# Altium schematic sheet 9-Other_Inputs.SchDoc(9-Other_Inputs)
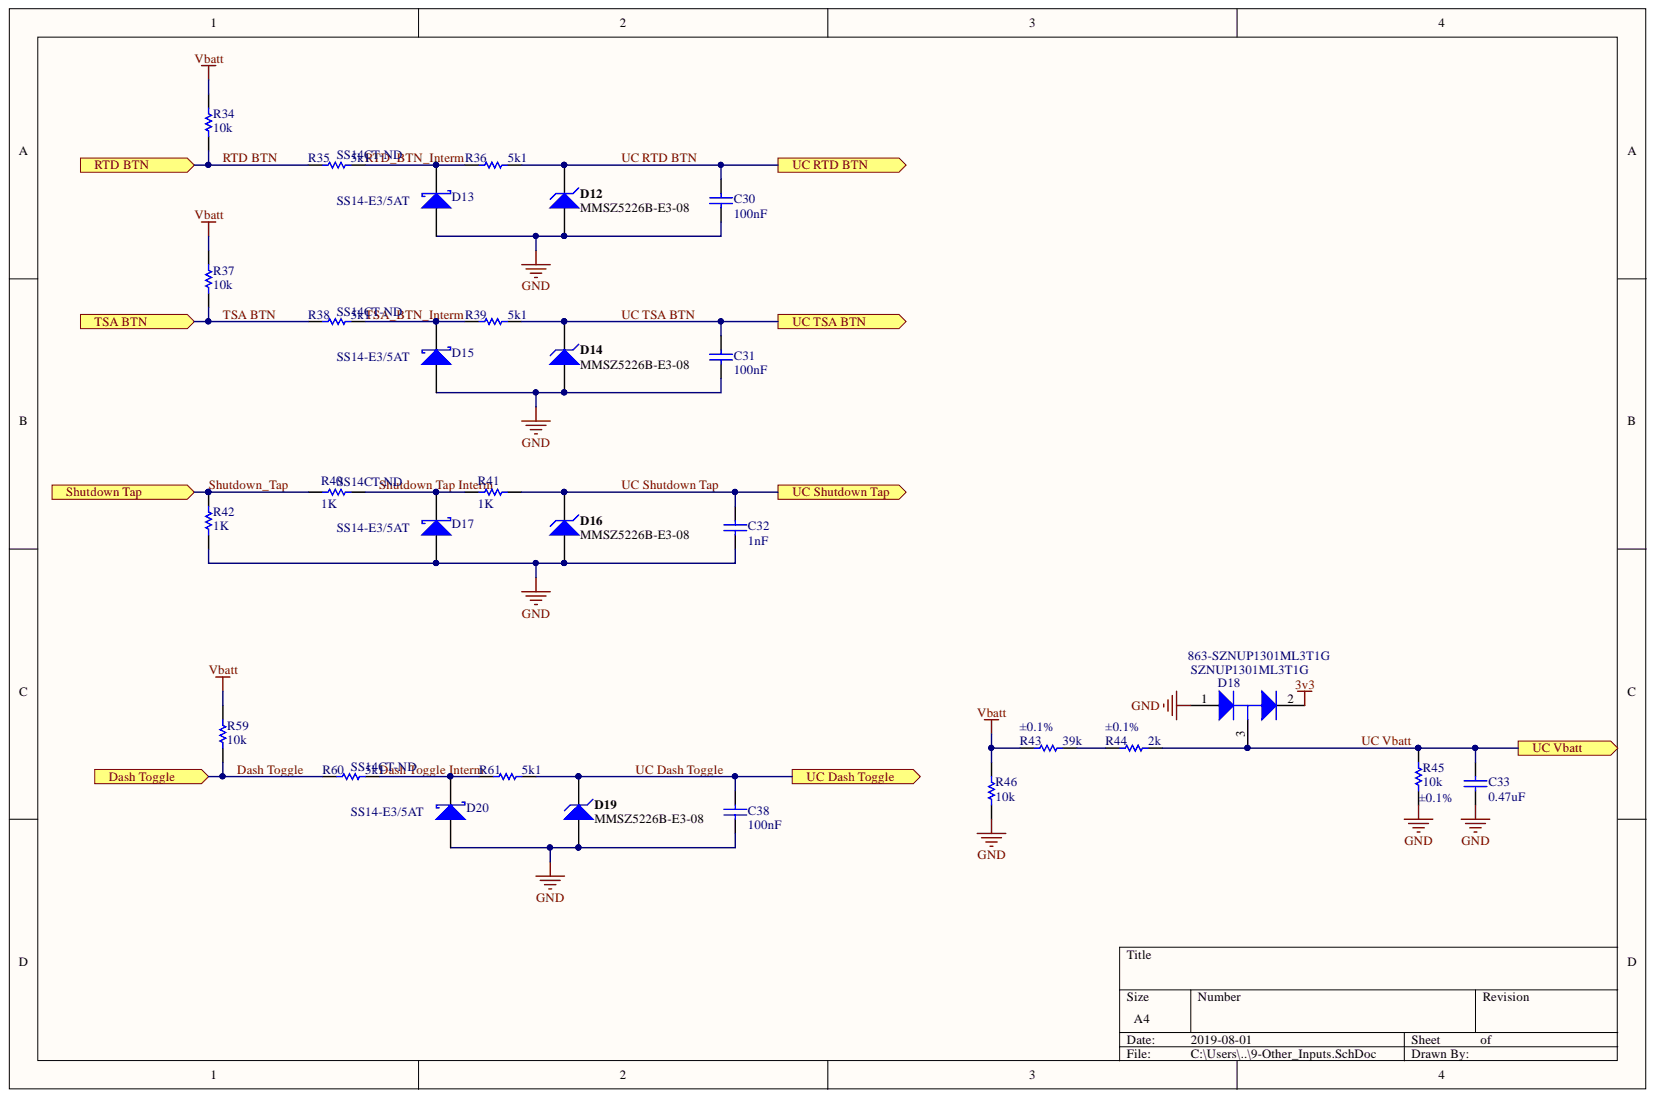
[diagram p0: 9-Other_Inputs.SchDoc]

## Parts
C30  value 100nF  [1608[0603]]  (hidden comment: Cap)
C31  value 100nF  [1608[0603]]  (hidden comment: Cap)
C32  value 1nF  [1608[0603]]  (hidden comment: Cap)
C33  value 0.47uF  [1608[0603]]  (hidden comment: Cap)
C38  value 100nF  [1608[0603]]  (hidden comment: Cap)
D12  MMSZ5226B-E3-08  [VISH-SOD-123-2_V]
D13  SS14-E3/5AT  [VISH-DO-214AC-2_V]
D14  MMSZ5226B-E3-08  [VISH-SOD-123-2_V]
D15  SS14-E3/5AT  [VISH-DO-214AC-2_V]
D16  MMSZ5226B-E3-08  [VISH-SOD-123-2_V]
D17  SS14-E3/5AT  [VISH-DO-214AC-2_V]
D18  SZNUP1301ML3T1G  [ONSC-SOT-23-3-318-08_V]
D19  MMSZ5226B-E3-08  [VISH-SOD-123-2_V]
D20  SS14-E3/5AT  [VISH-DO-214AC-2_V]
R34  value 10k  [1608[0603]]  (hidden comment: Res1)
R35  value 5k1  [1608[0603]]  (hidden comment: Res1)
R36  value 5k1  [1608[0603]]  (hidden comment: Res1)
R37  value 10k  [1608[0603]]  (hidden comment: Res1)
R38  value 5k1  [1608[0603]]  (hidden comment: Res1)
R39  value 5k1  [1608[0603]]  (hidden comment: Res1)
R40  value 1K  [1608[0603]]  (hidden comment: Res1)
R41  value 1K  [1608[0603]]  (hidden comment: Res1)
R42  value 1K  [1608[0603]]  (hidden comment: Res1)
R43  value 39k  Tolerance: ±0.1%  [1608[0603]]  (hidden comment: Res1)
R44  value 2k  Tolerance: ±0.1%  [1608[0603]]  (hidden comment: Res1)
R45  value 10k  Tolerance: ±0.1%  [1608[0603]]  (hidden comment: Res1)
R46  value 10k  [1608[0603]]  (hidden comment: Res1)
R59  value 10k  [1608[0603]]  (hidden comment: Res1)
R60  value 5k1  [1608[0603]]  (hidden comment: Res1)
R61  value 5k1  [1608[0603]]  (hidden comment: Res1)

## Ports
Dash Toggle, RTD BTN, Shutdown Tap, TSA BTN, UC Dash Toggle, UC RTD BTN, UC Shutdown Tap, UC TSA BTN, UC Vbatt

## Nets
3v3: D18.2
GND: C30.1 C31.1 C32.1 C33.1 C38.1 D12.1 D13.1 D14.1 D15.1 D16.1 D17.1 D18.1 D19.1 D20.1 R42.1 R45.1 R46.1
Vbatt: R34.2 R37.2 R43.1 R46.2 R59.2
Dash Toggle: R59.1 R60.1 ; port Dash Toggle
Dash Toggle Interm: D20.2 R60.2 R61.1
RTD BTN: R34.1 R35.1 ; port RTD BTN
RTD_BTN_Interm: D13.2 R35.2 R36.1
Shutdown Tap Interm: D17.2 R40.1 R41.2
Shutdown_Tap: R40.2 R42.2 ; port Shutdown Tap
TSA BTN: R37.1 R38.1 ; port TSA BTN
TSA_BTN_Interm: D15.2 R38.2 R39.1
UC Dash Toggle: C38.2 D19.2 R61.2 ; port UC Dash Toggle
UC RTD BTN: C30.2 D12.2 R36.2 ; port UC RTD BTN
UC Shutdown Tap: C32.2 D16.2 R41.1 ; port UC Shutdown Tap
UC TSA BTN: C31.2 D14.2 R39.2 ; port UC TSA BTN
UC Vbatt: C33.2 D18.3 R44.2 R45.2 ; port UC Vbatt
NetR43_2: R43.2 R44.1

## Library data of the parts
C30 C31 C38 = Cap: Capacitor; Manufacturer Part Number: CC0603KRX7R9BB104; Manufacturer: Yageo; Voltage - Rated: 50V; Tolerance: ±10%; Temperature Coefficient: X7R; Capacitance: 0.10µF; Thickness (Max): 0.035" (0.90mm); Supplier Part Number 1: 311-1344-1-ND; Supplier 1: Digi-Key; Stock 1: 4016262; Size / Dimension: 0.063" L x 0.031" W (1.60mm x 0.80mm); Simulation: CAP; Signal Integrity: Cap; RoHS: RoHS Compliant; Ratings: -; Pricing 1: 1=0.15, 10=0.043, 25=0.0312, 50=0.0232, 100=0.0189, 250=0.0158, 500=0.01346, 1000=0.01059 (CAD); Part Status: Active; Packaging: Cut Tape (CT); Package / Case: 0603 (1608 Metric); Operating Temperature: -55°C ~ 125°C; Mounting Type: Surface Mount, MLCC; Library Name: Miscellaneous Devices.IntLib; Lead Style: -; Lead Spacing: -; Height - Seated (Max): -; Features: -; Failure Rate: -; ComponentLink1URL: http://www.yageo.com/documents/recent/UPY-GPHC_X7R_6.3V-to-50V_16.pdf; ComponentLink1Description: http://www.yageo.com/documents/recent/UPY-GPHC_X7R_6.3V-to-50V_16.pdf; Component Kind: Standard; Category: Capacitors; Applications: General Purpose
C32 = Cap: Capacitor; Manufacturer Part Number: CC0603KRX7R9BB102; Manufacturer: Yageo; Voltage - Rated: 50V; Tolerance: ±10%; Temperature Coefficient: X7R; Capacitance: 1000pF; Thickness (Max): 0.035" (0.90mm); Supplier Part Number 1: 311-1080-1-ND; Supplier 1: Digi-Key; Stock 1: 783486; Size / Dimension: 0.063" L x 0.031" W (1.60mm x 0.80mm); Simulation: CAP; Signal Integrity: Cap; RoHS: RoHS Compliant; Ratings: -; Pricing 1: 1=0.15, 10=0.029, 25=0.0212, 50=0.0158, 100=0.0129, 250=0.01084, 500=0.00928, 1000=0.00729 (CAD); Part Status: Active; Packaging: Cut Tape (CT); Package / Case: 0603 (1608 Metric); Operating Temperature: -55°C ~ 125°C; Mounting Type: Surface Mount, MLCC; Library Name: Miscellaneous Devices.IntLib; Lead Style: -; Lead Spacing: -; Height - Seated (Max): -; Features: -; Failure Rate: -; ComponentLink1URL: http://www.yageo.com/documents/recent/UPY-GPHC_X7R_6.3V-to-50V_16.pdf; ComponentLink1Description: http://www.yageo.com/documents/recent/UPY-GPHC_X7R_6.3V-to-50V_16.pdf; Component Kind: Standard; Category: Capacitors; Applications: General Purpose
C33 = Cap: Capacitor; Manufacturer Part Number: CC0603KRX7R8BB474; Manufacturer: Yageo; Voltage - Rated: 25V; Tolerance: ±10%; Temperature Coefficient: X7R; Capacitance: 0.47µF; Thickness (Max): 0.035" (0.90mm); Supplier Part Number 1: 311-1805-1-ND; Supplier 1: Digi-Key; Stock 1: 11076; Size / Dimension: 0.063" L x 0.031" W (1.60mm x 0.80mm); Simulation: CAP; Signal Integrity: Cap; RoHS: RoHS Compliant; Ratings: -; Pricing 1: 1=0.22, 10=0.158, 25=0.1156, 50=0.0898, 100=0.0745, 250=0.06168, 500=0.05226, 1000=0.04324 (CAD); Part Status: Active; Packaging: Cut Tape (CT); Package / Case: 0603 (1608 Metric); Operating Temperature: -55°C ~ 125°C; Mounting Type: Surface Mount, MLCC; Library Name: Miscellaneous Devices.IntLib; Lead Style: -; Lead Spacing: -; Height - Seated (Max): -; Features: -; Failure Rate: -; ComponentLink1URL: http://www.yageo.com/documents/recent/UPY-GPHC_X7R_6.3V-to-50V_16.pdf; ComponentLink1Description: http://www.yageo.com/documents/recent/UPY-GPHC_X7R_6.3V-to-50V_16.pdf; Component Kind: Standard; Category: Capacitors; Applications: General Purpose
D12 D14 D16 D19 = CMP-0931-00026-1: Small Signal Zener Diode, 3.3 V +/- 5%, -55 to 155 degC, 2-Pin SOD-123, RoHS, Tape and Reel; Manufacturer Part Number: MMSZ5226B-7-F; Manufacturer: Vishay Semiconductor; Zener Voltage (NOM.) (V): 3.3; Zener Impedance (IZT1) (Max.) (Ohm): 28; Voltage - Zener (Nom) (Vz): 3.3V; Voltage - Forward (Vf) (Max) @ If: 900mV @ 10mA; Tolerance: +/- 5%; Test Current (IZT1) (mA): 20; Power Dissipation (mW): 500; Power - Max: 500mW; Int. Construction: Single; Impedance (Max) (Zzt): 28 Ohm; Current - Reverse Leakage @ Vr: 25µA @ 1V; Supplier Part Number 1: MMSZ5226B-FDICT-ND; Supplier Device Package: SOD-123; Supplier 1: Digi-Key; Stock 1: 45935; RoHS: TRUE; Pricing 1: 1=0.29, 10=0.263, 100=0.1426, 500=0.08778, 1000=0.05985 (CAD); Part Status: Active; PartNumber: MMSZ5226B-E3-08; Packing: Tape and Reel; Packaging: Cut Tape (CT); PackageVersion: Rev. 1.9; PackageReference: SOD-123-2; PackageDescription: 2-Pin SMD, Body 2.7 x 1.55 mm, Height 1.35 mm; Package / Case: SOD-123; Operating Temperature: -55 to 150 degC; Mounting Type: Surface Mount; Mounting Technology: Surface Mount; Library Name: Altium Content Vault; DatasheetVersion: Rev. 1.9; ComponentLink4URL: http://www.diodes.com/_files/datasheets/ds18010.pdf; ComponentLink4Description: http://www.diodes.com/_files/datasheets/ds18010.pdf; ComponentLink3URL: http://www.vishay.com/docs/85774/mmsz5225.pdf; ComponentLink3Description: Package Specification; ComponentLink2URL: http://www.vishay.com/docs/85774/mmsz5225.pdf; ComponentLink2Description: Datasheet; ComponentLink1URL: http://www.vishay.com/; ComponentLink1Description: Manufacturer URL; Component Kind: Standard; Category: Discrete Semiconductor Products
D13 D15 D17 D20 = CMP-0549-00004-1: Schottky Barrier Rectifier, 40 V Maximum DC Blocking Voltage, -65 to 125 degC, 2-Pin SMA Case, RoHS; Manufacturer: Vishay Semiconductor; VRRM: 40 V Max.; VRMS: 28 V Max.; IFSM: 40 A; IF(AV): 1 A Max.; VDC: 40 V Max.; Supplier Part Number 1: SS14CT-ND; Supplier 1: Digi-Key; RoHS: TRUE; PartNumber: SS14-E3/5AT; PackageVersion: Dec-12; PackageReference: DO-214AC-2; PackageDescription: 2-Pin SMD, Body 4.245 x 2.665 mm, Height 2.29 mm; Operating Temperature: -65 to 125 degC; Mounting Technology: Surface Mount; Library Name: Altium Content Vault; DatasheetVersion: 12/17/2012; ComponentLink3URL: http://www.vishay.com/docs/88746/ss12.pdf; ComponentLink3Description: Package Specification; ComponentLink2URL: http://www.vishay.com/docs/88746/ss12.pdf; ComponentLink2Description: Datasheet; ComponentLink1URL: http://www.vishay.com/; ComponentLink1Description: Manufacturer URL; Component Kind: Standard
D18 = CMP-1063-00171-1: Low Capacitance Diode Array for ESD Protection in a Single Data Line, 3-Pin SOT-23, Pb-Free, Tape and Reel; Manufacturer: On Semiconductor; VRWM Max (V): 70; Number of Lines: 1; Direction: Bidirectional; C Max (pF): 0.9; V(BR) Min (V): 70; Supplier Part Number 1: 863-SZNUP1301ML3T1G; Supplier 1: Mouser; RoHS: Pb-Free; PartNumber: SZNUP1301ML3T1G; Packing: Tape and Reel; PackageVersion: Rev. AP, 11/2009; PackageReference: SOT-23-3-318-08; PackageDescription: 3-Pin Small Outline Transistor, Body 2.92 x 1.3 mm, Pitch 0.95 mm; Mounting Technology: Surface Mount; Library Name: Altium Content Vault; DatasheetVersion: Rev. 6; ComponentLink3URL: http://www.onsemi.cn/pub_link/Collateral/318-08.PDF; ComponentLink3Description: Package Specification; ComponentLink2URL: http://www.onsemi.cn/pub_link/Collateral/NUP1301ML3T1-D.PDF; ComponentLink2Description: Datasheet; ComponentLink1URL: http://www.onsemi.com/; ComponentLink1Description: Manufacturer URL; Component Kind: Standard
R34 R37 R46 R59 = Res1: Resistor; Manufacturer Part Number: RC0603JR-0710KL; Manufacturer: Yageo; Tolerance: ±5%; Temperature Coefficient: ±100ppm/°C; Power (Watts): 0.1W, 1/10W; Supplier Part Number 1: 311-10KGRCT-ND; Supplier Device Package: 0603; Supplier 1: Digi-Key; Stock 1: 2936292; Size / Dimension: 0.063" L x 0.031" W (1.60mm x 0.80mm); Simulation: RESISTOR; Signal Integrity: Res; RoHS: RoHS Compliant; Resistance (Ohms): 10k; Pricing 1: 1=0.16, 10=0.016, 25=0.0112, 50=0.0084, 100=0.0062, 250=0.0048, 500=0.00382, 1000=0.00281 (CAD); Part Status: Active; Packaging: Cut Tape (CT); Package / Case: 0603 (1608 Metric); Operating Temperature: -55°C ~ 155°C; Number of Terminations: 2; Library Name: Miscellaneous Devices.IntLib; Height - Seated (Max): 0.022" (0.55mm); Features: Moisture Resistant; Failure Rate: -; Composition: Thick Film; ComponentLink1URL: http://www.yageo.com/NewPortal/yageodocoutput?fileName=/pdf/R-Chip/PYu-RC_Group_51_RoHS_L_4.pdf; ComponentLink1Description: http://www.yageo.com/NewPortal/yageodocoutput?fileName=/pdf/R-Chip/PYu-RC_Group_51_RoHS_L_4.pdf; Component Kind: Standard; Category: Resistors
R35 R36 R38 R39 R60 R61 = Res1: Resistor; Manufacturer Part Number: RC0603JR-075K1L; Manufacturer: Yageo; Tolerance: ±5%; Temperature Coefficient: ±100ppm/°C; Resistance (Ohms): 5.1k; Power (Watts): 0.1W, 1/10W; Supplier Part Number 1: 311-5.1KGRCT-ND; Supplier Device Package: 0603; Supplier 1: Digi-Key; Stock 1: 782184; Size / Dimension: 0.063" L x 0.031" W (1.60mm x 0.80mm); Simulation: RESISTOR; Signal Integrity: Res; RoHS: RoHS Compliant; Pricing 1: 1=0.16, 10=0.016, 25=0.0112, 50=0.0084, 100=0.0062, 250=0.0048, 500=0.00382, 1000=0.00281 (CAD); Part Status: Active; Packaging: Cut Tape (CT); Package / Case: 0603 (1608 Metric); Operating Temperature: -55°C ~ 155°C; Number of Terminations: 2; Library Name: Miscellaneous Devices.IntLib; Height - Seated (Max): 0.022" (0.55mm); Features: Moisture Resistant; Failure Rate: -; Composition: Thick Film; ComponentLink1URL: http://www.yageo.com/NewPortal/yageodocoutput?fileName=/pdf/R-Chip/PYu-RC_Group_51_RoHS_L_4.pdf; ComponentLink1Description: http://www.yageo.com/NewPortal/yageodocoutput?fileName=/pdf/R-Chip/PYu-RC_Group_51_RoHS_L_4.pdf; Component Kind: Standard; Category: Resistors
R40-R42 = Res1: Resistor; Manufacturer Part Number: ERJ-PA3F1001V; Manufacturer: Panasonic Electronic Components; Tolerance: ±1%; Temperature Coefficient: ±100ppm/°C; Power (Watts): 0.25W, 1/4W; Supplier Part Number 1: P1.0KBYCT-ND; Supplier Device Package: 0603; Supplier 1: Digi-Key; Stock 1: 56110; Size / Dimension: 0.063" L x 0.031" W (1.60mm x 0.80mm); Simulation: RESISTOR; Signal Integrity: Res; RoHS: RoHS Compliant; Resistance (Ohms): 1k; Pricing 1: 1=0.22, 10=0.198, 25=0.138, 50=0.1072, 100=0.0765, 250=0.05972, 500=0.04746, 1000=0.03368 (CAD); Part Status: Active; Packaging: Cut Tape (CT); Package / Case: 0603 (1608 Metric); Operating Temperature: -55°C ~ 155°C; Number of Terminations: 2; Library Name: Miscellaneous Devices.IntLib; Height - Seated (Max): 0.022" (0.55mm); Features: Automotive AEC-Q200, Pulse Withstanding; Failure Rate: -; Composition: Thick Film; ComponentLink1URL: https://industrial.panasonic.com/cdbs/www-data/pdf/RDA0000/AOA0000C243.pdf; ComponentLink1Description: https://industrial.panasonic.com/cdbs/www-data/pdf/RDA0000/AOA0000C243.pdf; Component Kind: Standard; Category: Resistors
R43 = Res1: Resistor; Manufacturer Part Number: RT0603BRD0739KL; Manufacturer: Yageo; Temperature Coefficient: ±25ppm/°C; Power (Watts): 0.1W, 1/10W; Supplier Part Number 1: YAG1649CT-ND; Supplier Device Package: 0603; Supplier 1: Digi-Key; Stock 1: 2740; Size / Dimension: 0.063" L x 0.031" W (1.60mm x 0.80mm); Simulation: RESISTOR; Signal Integrity: Res; RoHS: RoHS Compliant; Resistance (Ohms): 39k; Pricing 1: 1=0.55, 10=0.464, 25=0.3376, 50=0.2446, 100=0.1814, 250=0.1392, 500=0.10966, 1000=0.07592 (CAD); Part Status: Active; Packaging: Cut Tape (CT); Package / Case: 0603 (1608 Metric); Operating Temperature: -55°C ~ 155°C; Number of Terminations: 2; Library Name: Miscellaneous Devices.IntLib; Height - Seated (Max): 0.022" (0.55mm); Features: Moisture Resistant; Failure Rate: -; Composition: Thin Film; ComponentLink1URL: http://www.yageo.com/documents/recent/PYu-RT_1-to-0.05_RoHS_L_6.pdf; ComponentLink1Description: http://www.yageo.com/documents/recent/PYu-RT_1-to-0.05_RoHS_L_6.pdf; Component Kind: Standard; Category: Resistors
R44 = Res1: Resistor; Manufacturer Part Number: AT0603BRD072KL; Manufacturer: Yageo; Temperature Coefficient: ±25ppm/°C; Power (Watts): 0.1W, 1/10W; Supplier Part Number 1: YAG2112CT-ND; Supplier Device Package: 0603; Supplier 1: Digi-Key; Stock 1: 1218; Size / Dimension: 0.063" L x 0.032" W (1.60mm x 0.80mm); Simulation: RESISTOR; Signal Integrity: Res; RoHS: RoHS Compliant; Resistance (Ohms): 2k; Pricing 1: 1=0.59, 10=0.431, 25=0.3188, 50=0.235, 100=0.1725, 250=0.14248, 500=0.11746, 1000=0.08498 (CAD); Part Status: Active; Packaging: Cut Tape (CT); Package / Case: 0603 (1608 Metric); Operating Temperature: -55°C ~ 155°C; Number of Terminations: 2; Library Name: Miscellaneous Devices.IntLib; Height - Seated (Max): 0.022" (0.55mm); Features: Anti-Sulfur, Automotive AEC-Q200, Moisture Resistant; Failure Rate: -; Composition: Thin Film; ComponentLink1URL: http://www.yageo.com/documents/recent/PYu-AT_51_RoHS_L-3.pdf; ComponentLink1Description: http://www.yageo.com/documents/recent/PYu-AT_51_RoHS_L-3.pdf; Component Kind: Standard; Category: Resistors
R45 = Res1: Resistor; Manufacturer Part Number: RT0603BRD0710KL; Manufacturer: Yageo; Temperature Coefficient: ±25ppm/°C; Power (Watts): 0.1W, 1/10W; Supplier Part Number 1: YAG1236CT-ND; Supplier Device Package: 0603; Supplier 1: Digi-Key; Stock 1: 2958; Size / Dimension: 0.063" L x 0.031" W (1.60mm x 0.80mm); Simulation: RESISTOR; Signal Integrity: Res; RoHS: RoHS Compliant; Resistance (Ohms): 10k; Pricing 1: 1=0.5, 10=0.42, 25=0.3048, 50=0.2212, 100=0.164, 250=0.12592, 500=0.0992, 1000=0.06867 (CAD); Part Status: Active; Packaging: Cut Tape (CT); Package / Case: 0603 (1608 Metric); Operating Temperature: -55°C ~ 155°C; Number of Terminations: 2; Library Name: Miscellaneous Devices.IntLib; Height - Seated (Max): 0.022" (0.55mm); Features: Moisture Resistant; Failure Rate: -; Composition: Thin Film; ComponentLink1URL: http://www.yageo.com/documents/recent/PYu-RT_1-to-0.05_RoHS_L_6.pdf; ComponentLink1Description: http://www.yageo.com/documents/recent/PYu-RT_1-to-0.05_RoHS_L_6.pdf; Component Kind: Standard; Category: Resistors
